# Altium schematic sheet Top.SchDoc
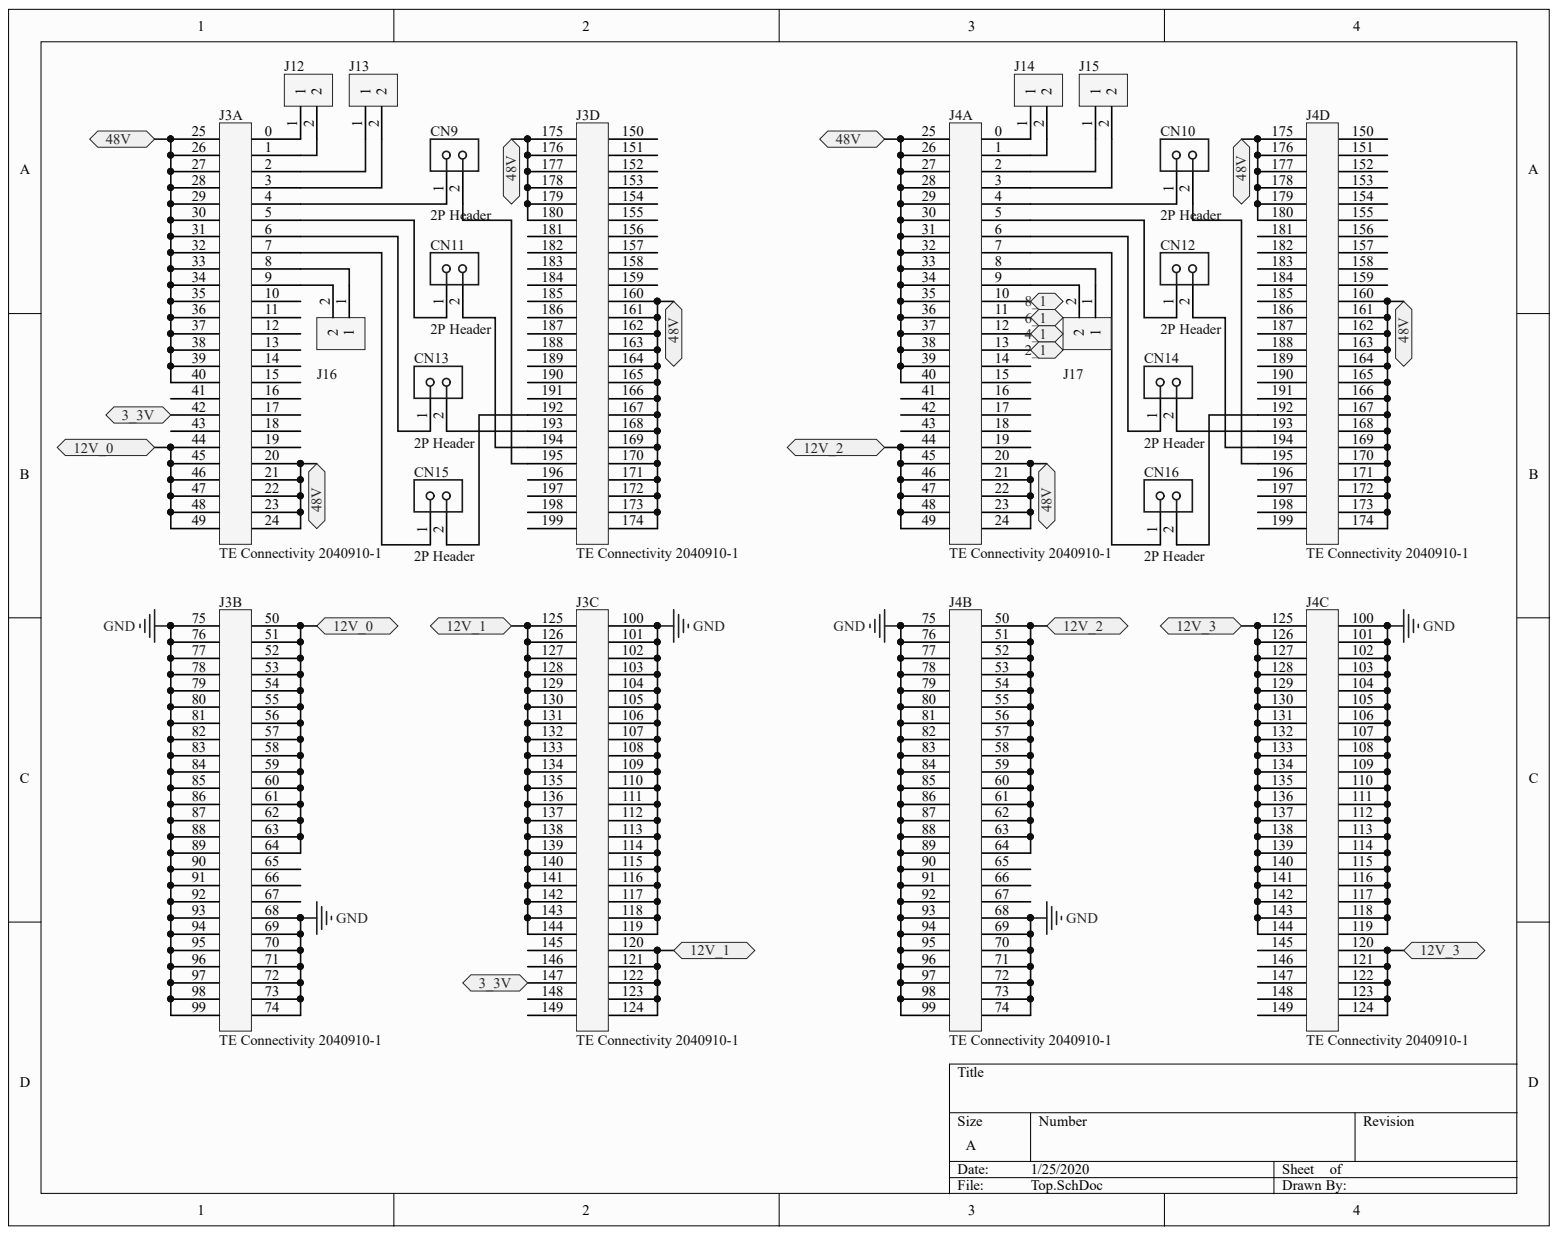
[diagram p0: Top.SchDoc]

## Parts
CN9  2P Header  [SIP2]
CN10  2P Header  [SIP2]
CN11  2P Header  [SIP2]
CN12  2P Header  [SIP2]
CN13  2P Header  [SIP2]
CN14  2P Header  [SIP2]
CN15  2P Header  [SIP2]
CN16  2P Header  [SIP2]
J3  TE Connectivity 2040910-1  Manufacturer Part Number: 2040910-1  [PCB-94s10idd7zcjgt3pt9oj-1]  (parts A,B,C,D)
J4  TE Connectivity 2040910-1  Manufacturer Part Number: 2040910-1  [PCB-94s10idd7zcjgt3pt9oj-1]  (parts A,B,C,D)
J12  Würth Elektronik 61300211121  [PCB-omgsbkhp90n7lpri88og-1]  (value not drawn)
J13  Würth Elektronik 61300211121  [PCB-omgsbkhp90n7lpri88og-1]  (value not drawn)
J14  Würth Elektronik 61300211121  [PCB-omgsbkhp90n7lpri88og-1]  (value not drawn)
J15  Würth Elektronik 61300211121  [PCB-omgsbkhp90n7lpri88og-1]  (value not drawn)
J16  Würth Elektronik 61300211121  [PCB-omgsbkhp90n7lpri88og-1]  (value not drawn)
J17  Würth Elektronik 61300211121  [PCB-omgsbkhp90n7lpri88og-1]  (value not drawn)

## Ports
2_1, 3_3V, 4_1, 6_1, 8_1, 12V_0, 12V_1, 12V_2, 12V_3, 48V

## Nets
GND: J3.68 J3.69 J3.70 J3.71 J3.72 J3.73 J3.74 J3.75 J3.76 J3.77 J3.78 J3.79 J3.80 J3.81 J3.82 J3.83 J3.84 J3.85 J3.86 J3.87 J3.88 J3.89 J3.90 J3.91 J3.92 J3.93 J3.94 J3.95 J3.96 J3.97 J3.98 J3.99 J3.100 J3.101 J3.102 J3.103 J3.104 J3.105 J3.106 J3.107 J3.108 J3.109 J3.110 J3.111 J3.112 J3.113 J3.114 J3.115 J3.116 J3.117 J3.118 J3.119 J4.68 J4.69 J4.70 J4.71 J4.72 J4.73 J4.74 J4.75 J4.76 J4.77 J4.78 J4.79 J4.80 J4.81 J4.82 J4.83 J4.84 J4.85 J4.86 J4.87 J4.88 J4.89 J4.90 J4.91 J4.92 J4.93 J4.94 J4.95 J4.96 J4.97 J4.98 J4.99 J4.100 J4.101 J4.102 J4.103 J4.104 J4.105 J4.106 J4.107 J4.108 J4.109 J4.110 J4.111 J4.112 J4.113 J4.114 J4.115 J4.116 J4.117 J4.118 J4.119
2_1: J4.13 ; port 2_1
3_3V: J3.42 J3.147 ; port 3_3V
4_1: J4.12 ; port 4_1
6_1: J4.11 ; port 6_1
8_1: J4.10 ; port 8_1
12V_0: J3.44 J3.45 J3.46 J3.47 J3.48 J3.49 J3.50 J3.51 J3.52 J3.53 J3.54 J3.55 J3.56 J3.57 J3.58 J3.59 J3.60 J3.61 J3.62 J3.63 J3.64 ; port 12V_0
12V_1: J3.120 J3.121 J3.122 J3.123 J3.124 J3.125 J3.126 J3.127 J3.128 J3.129 J3.130 J3.131 J3.132 J3.133 J3.134 J3.135 J3.136 J3.137 J3.138 J3.139 J3.140 J3.141 J3.142 J3.143 J3.144 ; port 12V_1
12V_2: J4.44 J4.45 J4.46 J4.47 J4.48 J4.49 J4.50 J4.51 J4.52 J4.53 J4.54 J4.55 J4.56 J4.57 J4.58 J4.59 J4.60 J4.61 J4.62 J4.63 J4.64 ; port 12V_2
12V_3: J4.120 J4.121 J4.122 J4.123 J4.124 J4.125 J4.126 J4.127 J4.128 J4.129 J4.130 J4.131 J4.132 J4.133 J4.134 J4.135 J4.136 J4.137 J4.138 J4.139 J4.140 J4.141 J4.142 J4.143 J4.144 ; port 12V_3
48V: J3.20 J3.21 J3.22 J3.23 J3.24 J3.25 J3.26 J3.27 J3.28 J3.29 J3.30 J3.31 J3.32 J3.33 J3.34 J3.35 J3.36 J3.37 J3.38 J3.39 J3.40 J3.160 J3.161 J3.162 J3.163 J3.164 J3.165 J3.166 J3.167 J3.168 J3.169 J3.170 J3.171 J3.172 J3.173 J3.174 J3.175 J3.176 J3.177 J3.178 J3.179 J3.180 J4.20 J4.21 J4.22 J4.23 J4.24 J4.25 J4.26 J4.27 J4.28 J4.29 J4.30 J4.31 J4.32 J4.33 J4.34 J4.35 J4.36 J4.37 J4.38 J4.39 J4.40 J4.160 J4.161 J4.162 J4.163 J4.164 J4.165 J4.166 J4.167 J4.168 J4.169 J4.170 J4.171 J4.172 J4.173 J4.174 J4.175 J4.176 J4.177 J4.178 J4.179 J4.180 ; port 48V
NetCN9_1: CN9.1 J3.4
NetCN9_2: CN9.2 J3.195
NetCN10_1: CN10.1 J4.4
NetCN10_2: CN10.2 J4.195
NetCN11_1: CN11.1 J3.5
NetCN11_2: CN11.2 J3.194
NetCN12_1: CN12.1 J4.5
NetCN12_2: CN12.2 J4.194
NetCN13_1: CN13.1 J3.6
NetCN13_2: CN13.2 J3.193
NetCN14_1: CN14.1 J4.6
NetCN14_2: CN14.2 J4.193
NetCN15_1: CN15.1 J3.7
NetCN15_2: CN15.2 J3.192
NetCN16_1: CN16.1 J4.7
NetCN16_2: CN16.2 J4.192
NetJ3_0: J3.0 J12.1
NetJ3_1: J3.1 J12.2
NetJ3_2: J3.2 J13.1
NetJ3_3: J3.3 J13.2
NetJ3_8: J3.8 J16.1
NetJ3_9: J3.9 J16.2
NetJ4_0: J4.0 J14.1
NetJ4_1: J4.1 J14.2
NetJ4_2: J4.2 J15.1
NetJ4_3: J4.3 J15.2
NetJ4_8: J4.8 J17.1
NetJ4_9: J4.9 J17.2

Not connected: J3 10 11 12 13 14 15 16 17 18 19 41 43 65 66 67 145 146 148 149 150 151 152 153 154 155 156 157 158 159 181 182 183 184 185 186 187 188 189 190 191 196 197 198 199; J4 14 15 16 17 18 19 41 42 43 65 66 67 145 146 147 148 149 150 151 152 153 154 155 156 157 158 159 181 182 183 184 185 186 187 188 189 190 191 196 197 198 199

## Library data of the parts
CN9-CN16 = CMP-fc43341a67d62384-2: Conn Header .100" Sngl Str 2pos; Manufacturer Part Number: PRPC002SAAN-RC; Color: Black; Component Kind: Standard; Library Name: CommunityVault; Mounting Style: Through Hole; OctopartID: fc43341a67d62384; Octopart URL: http://octopart.com/prpc002saan-rc-sullins-22265587; Packaging: Bulk
J3 J4 = CMP-33f9f842d950ec3e-13: Conn SO DIMM Socket SKT 200 POS 0.6mm Solder ST SMD Tray/Box; ELV Compliant: Compliant; Component Kind: Standard; Contact Material: Copper Alloy; Contact Plating: Tin, Gold; Flammability Rating: UL 94 V-0; Housing Material: Thermoplastic; Library Name: CommunityVault; Lifecycle Status: Active; Mounting Style: Surface Mount, PCB; OctopartID: 33f9f842d950ec3e; Octopart URL: https://octopart.com/2040910-1-te+connectivity-45207503; Operating Temperature: -55.0 °C to 85.0 °C; Packaging: Tray, Box; RoHS: Compliant
J12-J17 = CMP-748fbcb73d6bab48-27: THT Vertical Pin Header WR-PHD, Pitch 2.54 mm, Single Row, 2 pins; Contact Resistance: 20.0 mΩ; Current Rating: 3.00 A; Dielectric Withstanding Voltage: 500 V; Gender: Male; Lead-Free Status: Lead Free; Number of Contacts: 2; Operating Voltage: 250 V; Component Kind: Standard; Contact Material: Copper; Contact Plating: Gold; Library Name: CommunityVault; Manufacturer Part Number: 61300211121; Mounting Style: Through Hole; Number of Pins: 2; OctopartID: 748fbcb73d6bab48; Operating Temperature: -40.0 °C (min); Orientation: Vertical; Packaging: Bag; REACH SVHC Compliance: No SVHC; RoHS: Compliant
